# Altium schematic sheet MCU_Power.SchDoc(MCU_Power)
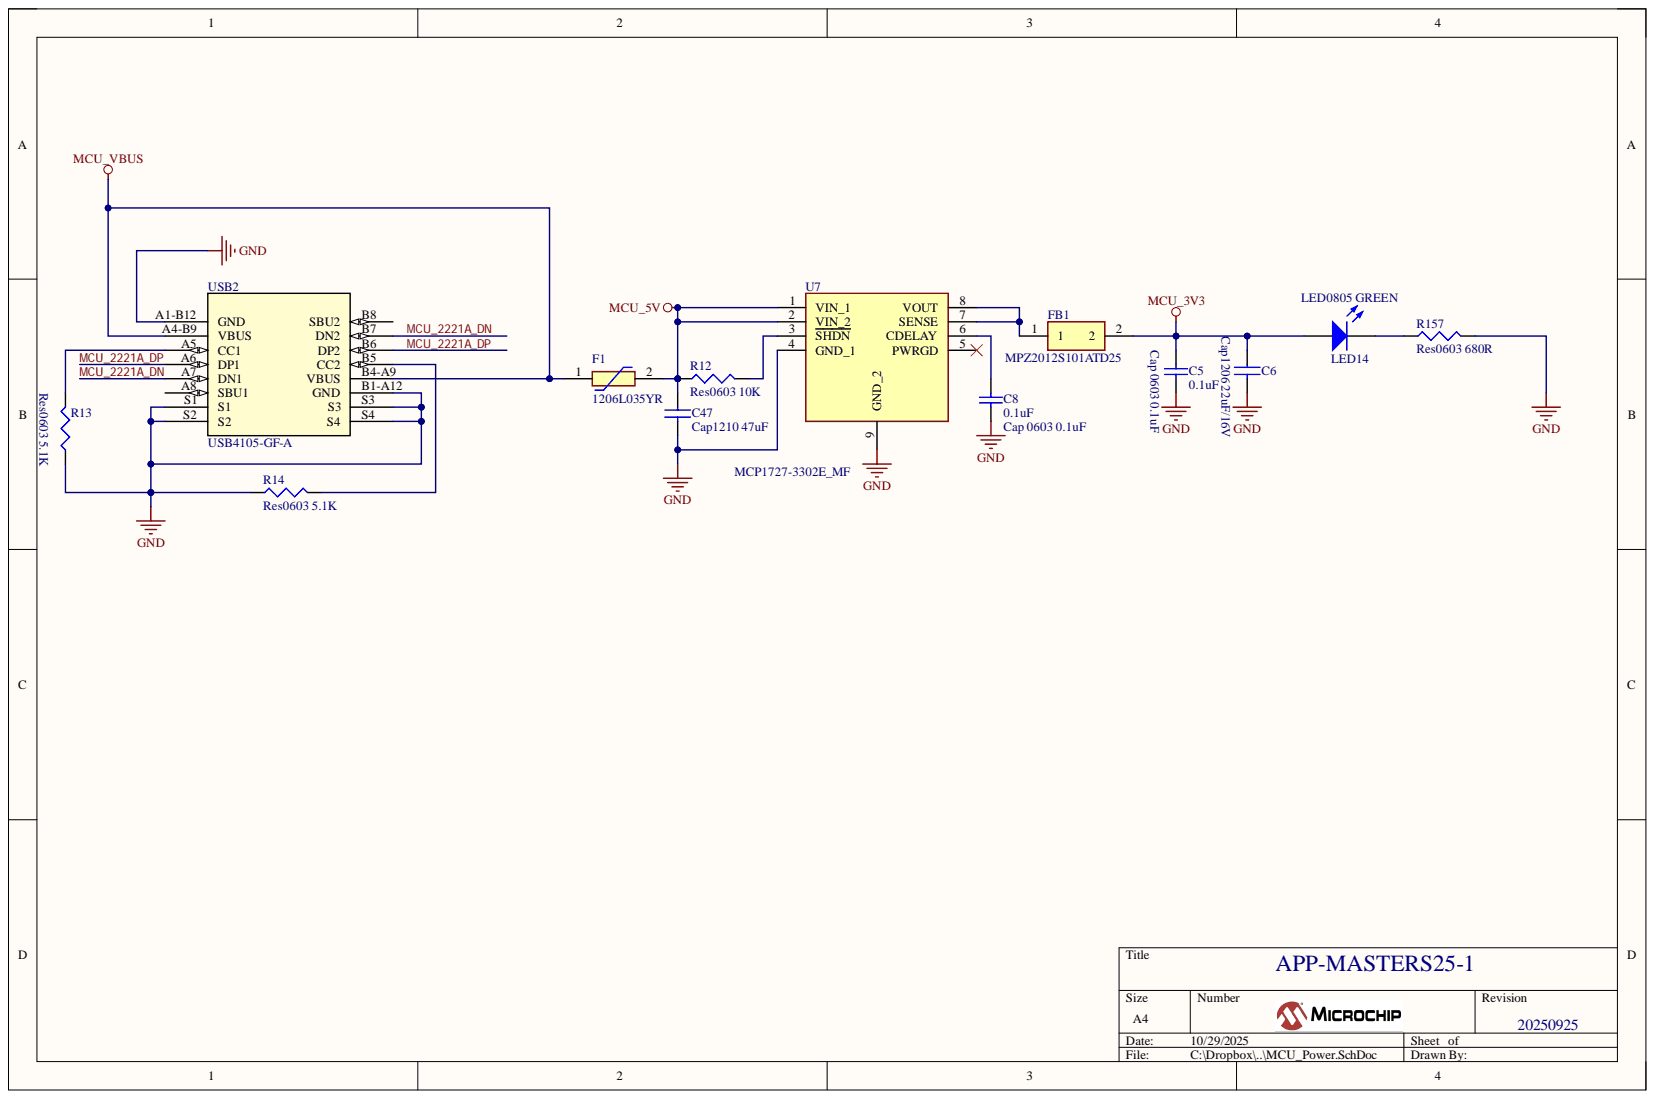
[diagram p0: MCU_Power.SchDoc]

## Parts
C5  Cap 0603 0.1uF  value 0.1uF  [0603C]
C6  Cap1206 22uF/16V  [AP1-00026]
C8  Cap 0603 0.1uF  value 0.1uF  [0603C]
C47  Cap1210 47uF  [C1210 NP]
F1  1206L035YR  [1206L05015YR]
FB1  MPZ2012S101ATD25  [BEADC2012X105N]
LED14  LED0805 GREEN  [LED0805_G]
R12  Res0603 10K  value 10K  [0603R]
R13  Res0603 5.1K  value 5.1K  [0603R]
R14  Res0603 5.1K  value 5.1K  [0603R]
R157  Res0603 680R  [0603R]
U7  MCP1727-3302E_MF  [SON65P300X300X100-9N-D]
USB2  USB4105-GF-A  [USB4105_GCT]

## Nets
GND: C5.1 C6.2 C8.1 C47.2 R13.1 R14.1 R157.2 U7.4(GND_1) U7.9(GND_2) USB2.A1-B12(GND) USB2.B1-A12(GND) USB2.S1 USB2.S2 USB2.S3 USB2.S4
MCU_3V3: C5.2 C6.1 FB1.2 LED14.1
MCU_5V: C47.1 F1.2 R12.1 U7.1(VIN_1) U7.2(VIN_2)
MCU_VBUS: F1.1 USB2.A4-B9(VBUS) USB2.B4-A9(VBUS)
MCU_2221A_DN: USB2.A7(DN1) USB2.B7(DN2)
MCU_2221A_DP: USB2.A6(DP1) USB2.B6(DP2)
NetC8_2: C8.2 U7.6(CDELAY)
NetFB1_1: FB1.1 U7.7(SENSE) U7.8(VOUT)
NetLED14_2: LED14.2 R157.1
NetR12_2: R12.2 U7.3(SHDN)
NetR13_2: R13.2 USB2.A5(CC1)
NetR14_2: R14.2 USB2.B5(CC2)

Not connected: U7 5(PWRGD); USB2 A8(SBU1) B8(SBU2)

## Library data of the parts
C5 C8 = Cap 0603: Capacitor (Semiconductor SIM Model); Component Kind: Standard; LatestRevisionDate: 29-May-2009; LatestRevisionNote: IPC-7351 Footprint Added.; Library Name: MCHP_Parts_2016.SchLib; PackageDescription: Chip Capacitor; PackageReference: C0603; Published: 8-Jun-2000; Publisher: Altium Limited
C6 = Cap1206 Ceramic: Ceramic capacitor, SMD 1206, X7R, 16V, Â±10 %; Manufacturer: Murata; MPN: GRM31CR71C106KA12L; PartGroup: Capacitors,Ceramic,SMD 1206; AN: A01-0289; TemperatureRange: -55 - 125 deg C; Tolerance: Â±10 %; Value: 22uF; Component Kind: Standard; Height: 1,79999995231628; Library Name: MCHP_Parts_2016.SchLib; Verified date: 2005-12-15
C47 = Cap1210 NP: Ceramic capacitor, SMD 1206, X7R, 16V, Â±10 %; Manufacturer: Murata; MPN: GRM31CR71C106KA12L; PartGroup: Capacitors,Ceramic,SMD 1206; AN: A01-0289; TemperatureRange: -55 - 125 deg C; Tolerance: Â±10 %; Value: 47uF/16V; Component Kind: Standard; Height: 1,79999995231628; Library Name: MCHP_Parts_2016.SchLib; Verified date: 2005-12-15
F1 = 1206L035YR: Resettable Fuse; Manufacturer_Name: LITTELFUSE; Arrow Part Number: 1206L035YR; Arrow Price/Stock: https://www.arrow.com/en/products/1206l035yr/littelfuse?region=nac; Component Kind: Standard; Datasheet Link: https://m.littelfuse.com/~/media/electronics/datasheets/resettable_ptcs/littelfuse_ptc_1206l_datasheet.pdf.pdf; Height: 0.75mm; Library Name: SamacSys.SchLib; Manufacturer_Part_Number: 1206L035YR; Mouser Part Number: 576-1206L035YR; Mouser Price/Stock: https://www.mouser.co.uk/ProductDetail/Littelfuse/1206L035YR?qs=PWhpLWeW8wd%2F%252B9d2B1EXww%3D%3D
FB1 = MPZ2012S221AT000: Ferrite Bead; Manufacturer_Name: TDK; Arrow Part Number: MPZ2012S221AT000; Arrow Price/Stock: https://www.arrow.com/en/products/mpz2012s221at000/tdk?region=nac; Component Kind: Standard; Datasheet Link: https://product.tdk.com/system/files/dam/doc/product/emc/emc/beads/catalog/beads_commercial_power_mpz2012_en.pdf; Height: 1.05mm; Library Name: SamacSys.SchLib; Manufacturer_Part_Number: MPZ2012S221AT000; Mouser Part Number: 810-MPZ2012S221AT000; Mouser Price/Stock: https://www.mouser.co.uk/ProductDetail/TDK/MPZ2012S221AT000?qs=pLY5GE0xrmJYuLs3YjLRbg%3D%3D
LED14 = LED0805 GREEN: Typical BLUE SiC LED; Component Kind: Standard; DatasheetVersion: 16-Apr-2002; LatestRevisionDate: 17-Dec-2003; LatestRevisionNote: PCB Footprint replaced.; Library Name: MCHP_Parts_2016.SchLib; PackageDescription: SM LED; 2 C-Bend Leads; Body 3.5 x 2.8 x 1.9 mm, inc leads (LxWxH typ); PackageReference: 3.5X2.8X1.9; PackageVersion: 16-Apr-2002; Published: 8-Jun-2000; Publisher: Altium Limited
R12-R14 = Res0603: Resistor; Component Kind: Standard; LatestRevisionDate: 29-May-2009; LatestRevisionNote: IPC-7351 Footprint Added.; Library Name: MCHP_Parts_2016.SchLib; PackageDescription: Chip Resistor; PackageReference: J1-0603; Published: 8-Jun-2000; Publisher: Altium Limited
R157 = Res0603: Resistor; Value: 680; Component Kind: Standard; LatestRevisionDate: 29-May-2009; LatestRevisionNote: IPC-7351 Footprint Added.; Library Name: MCHP_Parts_2016.SchLib; PackageDescription: Chip Resistor; PackageReference: J1-0603; Published: 8-Jun-2000; Publisher: Altium Limited
U7 = MCP1727-3302E_MF: Integrated Circuit; Manufacturer_Name: Microchip; Manufacturer_Part_Number: MCP1727-3302E/MF; Arrow Part Number: MCP1727-3302E/MF; Arrow Price/Stock: https://www.arrow.com/en/products/mcp1727-3302emf/microchip-technology?utm_currency=USD&region=nac; Component Kind: Standard; Datasheet Link: http://ww1.microchip.com/downloads/en/DeviceDoc/21999b.pdf; Height: 1mm; Library Name: SamacSys.SchLib; Mouser Part Number: 579-MCP1727-3302E/MF; Mouser Price/Stock: https://www.mouser.co.uk/ProductDetail/Microchip-Technology/MCP1727-3302E-MF?qs=usxtMOJb1Ry%252BaKwf56olNA%3D%3D
USB2 = USB4105-GF-A: USB Type-C; Mfr_Name: Global Connector Technology; Component Kind: Standard; Library Name: MCHP_Parts_2016.SchLib; RefDes: RefDes; Type: Type
